# Altium schematic sheet Sheet1.SchDoc(Sheet1)
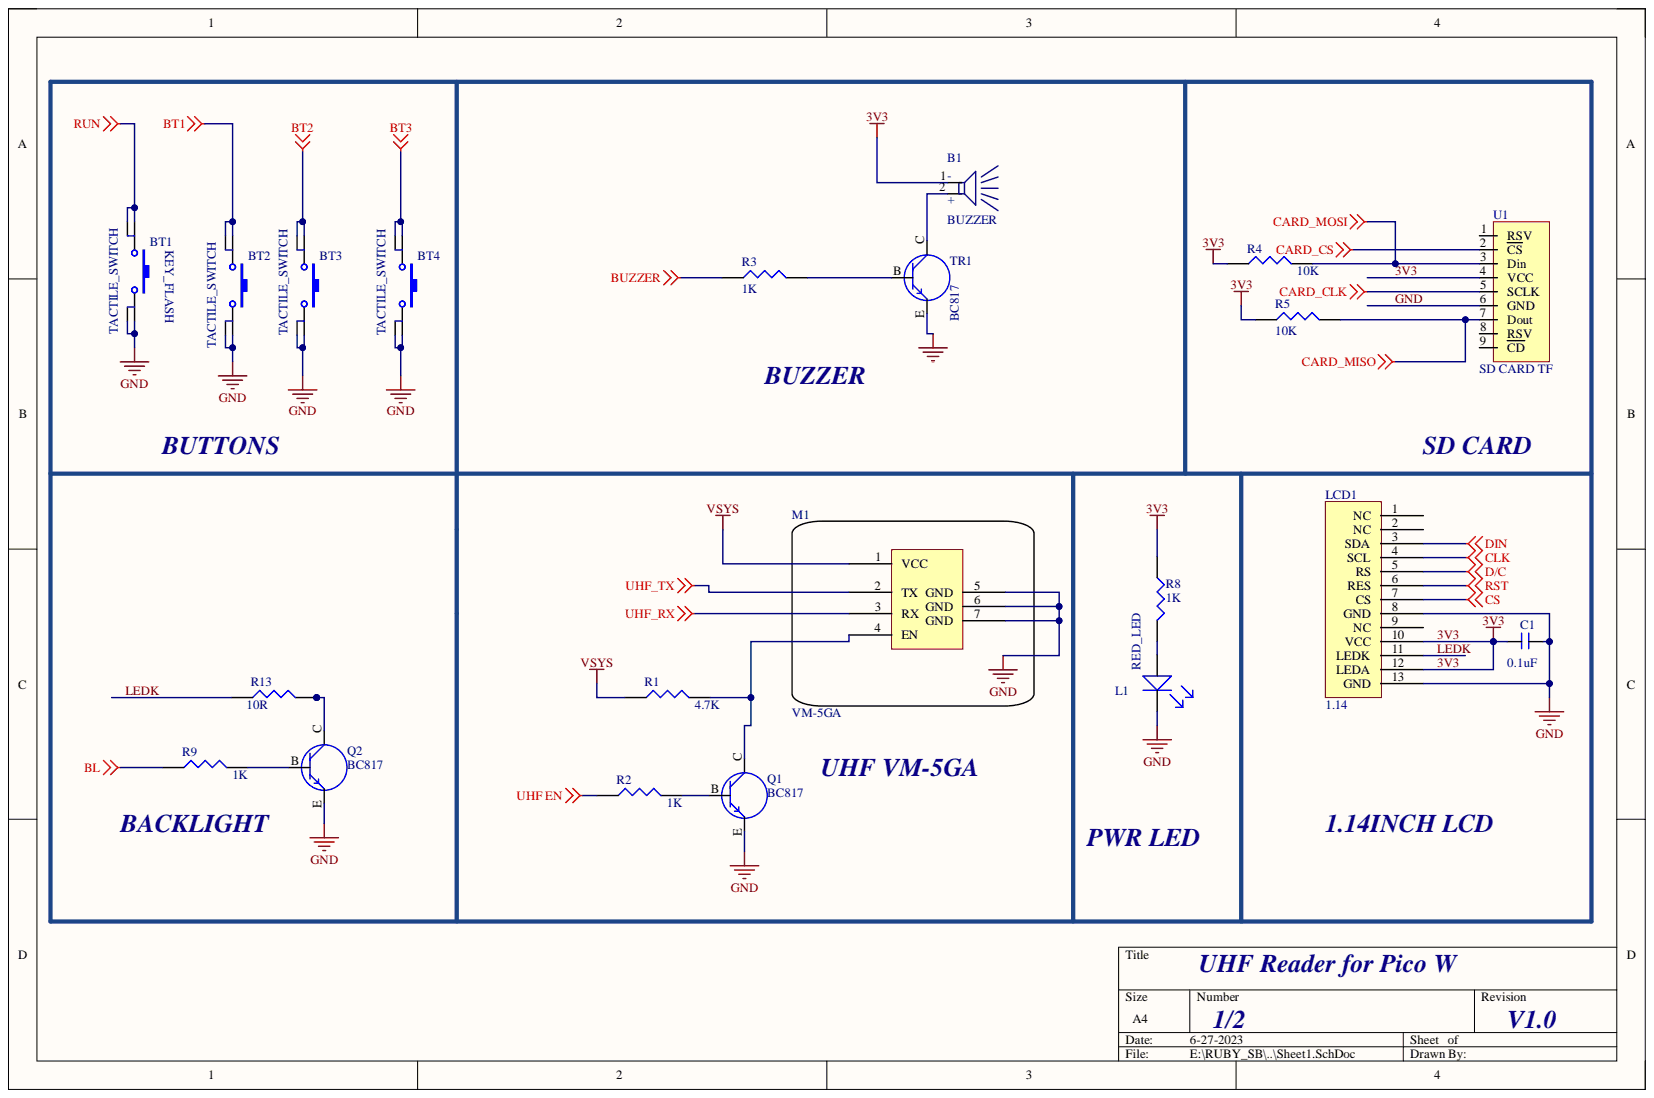
[diagram p0: Sheet1.SchDoc]

## Parts
B1  BUZZER  [SMD BUZZ]
BT1  TACTILE_SWITCH  [TACTILE]
BT2  TACTILE_SWITCH  [TACTILE]
BT3  TACTILE_SWITCH  [TACTILE]
BT4  TACTILE_SWITCH  [TACTILE]
C1  0.1uF  [C_0603]
L1  RED_LED  [LED_0603]
LCD1  1.14  [1.14 inch]
M1  VM-5GA  [UHF LITE WOD 3D]
Q1  BC817  [SOT23]
Q2  BC817  [SOT23]
R1  4.7K  [R_0603]
R2  1K  [R_0603]
R3  1K  [R_0603]
R4  10K  [R_0603]
R5  10K  [R_0603]
R8  1K  [R_0603]
R9  1K  [R_0603]
R13  10R  [R_0603]
TR1  BC817  [SOT23]
U1  SD CARD TF  [micro sd card]

## Nets
BL: R9.1
BT1: BT2.1 BT2.2
BT2: BT3.1 BT3.2
BT3: BT4.1 BT4.2
BUZZER: R3.1
CARD_CLK: U1.5(SCLK)
CARD_CS: U1.2(CS)
CARD_MISO: R5.2 U1.7(Dout)
CARD_MOSI: R4.2 U1.3(Din)
CLK: LCD1.4(SCL)
CS: LCD1.7(CS)
D/C: LCD1.5(RS)
DIN: LCD1.3(SDA)
RST: LCD1.6(RES)
RUN: BT1.1 BT1.2
UHF EN: R2.1
UHF_RX: M1.3(RX)
UHF_TX: M1.2(TX)
VSYS: M1.1(VCC) R1.1
3V3: B1.1 C1.2 LCD1.10(VCC) LCD1.12(LEDA) R4.1 R5.1 R8.1 U1.4(VCC)
GND: BT1.3 BT1.4 BT2.3 BT2.4 BT3.3 BT3.4 BT4.3 BT4.4 C1.1 L1.K LCD1.8(GND) LCD1.13(GND) M1.5(GND) M1.6(GND) M1.7(GND) Q1.E Q2.E TR1.E U1.6(GND)
LEDK: LCD1.11(LEDK) R13.1
NetB1_2: B1.2 TR1.C
NetL1_A: L1.A R8.2
NetM1_4: M1.4(EN) Q1.C R1.2
NetQ1_B: Q1.B R2.2
NetQ2_B: Q2.B R9.2
NetQ2_C: Q2.C R13.2
NetR3_2: R3.2 TR1.B

Not connected: LCD1 1(NC) 2(NC) 9(NC); U1 1(RSV) 8(RSV) 9(CD)

## Library data of the parts
B1 = BUZZER PIEZO: Component Kind: Standard; Library Name: dxp_pasl_lib.IntLib
C1 = CAP_NONPOLAR: Component Kind: Standard; Library Name: dxp_pasl_lib.IntLib
L1 = LED: Component Kind: Standard; Library Name: dxp_pasl_lib.IntLib
LCD1 = LCD 1.14INCH: 1.14inch LCD Display 65K RGB Colors, 240×135 Pixels, SPI Interface; Component Kind: Standard; Library Name: dxp_pasl_lib.IntLib
M1 = VIM-5GA: Component Kind: Standard; Library Name: MIX.SchLib
Q1 Q2 TR1 = TRANSISTER_NPN: Component Kind: Standard; Library Name: dxp_pasl_lib.IntLib
R1-R5 R8 R9 R13 = RES: Component Kind: Standard; Library Name: dxp_pasl_lib.IntLib
U1 = SD CARD TF: Micro SD Memory Card Socket; Component Kind: Standard; Library Name: dxp_pasl_lib.IntLib
BT1-BT4 = TACTILE_SWITCH: Component Kind: Standard; Library Name: dxp_pasl_lib.IntLib
